# Altium schematic sheet lumiere_ctrl_shematic.SchDoc
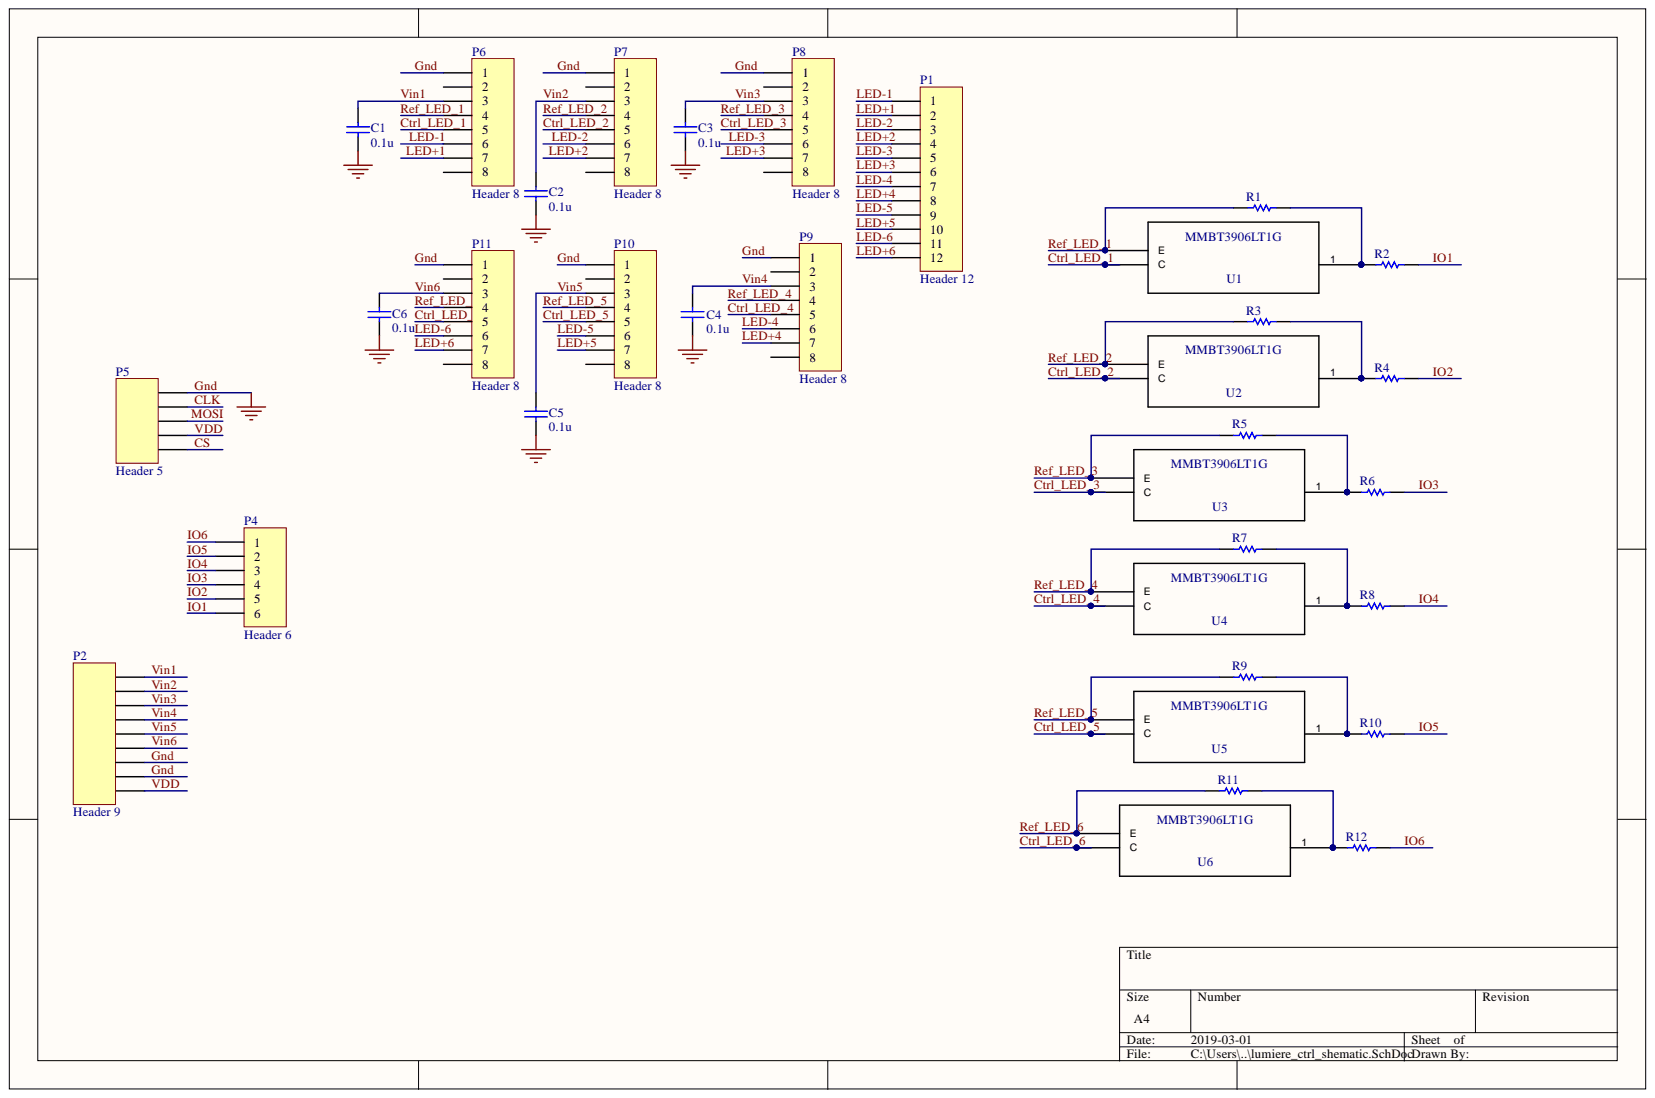
[diagram p0: lumiere_ctrl_shematic.SchDoc]

## Parts
C1  value 0.1u  [CC1206N]  (hidden comment: CapSMD)
C2  value 0.1u  [CC1206N]  (hidden comment: CapSMD)
C3  value 0.1u  [CC1206N]  (hidden comment: CapSMD)
C4  value 0.1u  [CC1206N]  (hidden comment: CapSMD)
C5  value 0.1u  [CC1206N]  (hidden comment: CapSMD)
C6  value 0.1u  [CC1206N]  (hidden comment: CapSMD)
P1  Header 12  [Terminal block 12 pos]
P2  Header 9  [Terminal block 9 pos]
P4  Header 6  [HDR1X6]
P5  Header 5  [HDR1X5]
P6  Header 8  [HDR1X8]
P7  Header 8  [HDR1X8]
P8  Header 8  [HDR1X8]
P9  Header 8  [HDR1X8]
P10  Header 8  [HDR1X8]
P11  Header 8  [HDR1X8]
R1  ResSMD  [STA_RMCF1206]  (value not drawn)
R2  ResSMD  [STA_RMCF1206]  (value not drawn)
R3  ResSMD  [STA_RMCF1206]  (value not drawn)
R4  ResSMD  [STA_RMCF1206]  (value not drawn)
R5  ResSMD  [STA_RMCF1206]  (value not drawn)
R6  ResSMD  [STA_RMCF1206]  (value not drawn)
R7  ResSMD  [STA_RMCF1206]  (value not drawn)
R8  ResSMD  [STA_RMCF1206]  (value not drawn)
R9  ResSMD  [STA_RMCF1206]  (value not drawn)
R10  ResSMD  [STA_RMCF1206]  (value not drawn)
R11  ResSMD  [STA_RMCF1206]  (value not drawn)
R12  ResSMD  [STA_RMCF1206]  (value not drawn)
U1  MMBT3906LT1G  [SOT-23]
U2  MMBT3906LT1G  [SOT-23]
U3  MMBT3906LT1G  [SOT-23]
U4  MMBT3906LT1G  [SOT-23]
U5  MMBT3906LT1G  [SOT-23]
U6  MMBT3906LT1G  [SOT-23]

## Nets
CLK: P5.4
CS: P5.1
Ctrl_LED_1: P6.5 U1.3
Ctrl_LED_2: P7.5 U2.3
Ctrl_LED_3: P8.5 U3.3
Ctrl_LED_4: P9.5 U4.3
Ctrl_LED_5: P10.5 U5.3
Ctrl_LED_6: P11.5 U6.3
Gnd: C1.1 C2.1 C3.1 C4.1 C5.1 C6.1 P2.2 P2.3 P5.5 P6.1 P7.1 P8.1 P9.1 P10.1 P11.1
IO1: P4.6 R2.1
IO2: P4.5 R4.1
IO3: P4.4 R6.1
IO4: P4.3 R8.1
IO5: P4.2 R10.1
IO6: P4.1 R12.1
LED+1: P1.2 P6.7
LED+2: P1.4 P7.7
LED+3: P1.6 P8.7
LED+4: P1.8 P9.7
LED+5: P1.10 P10.7
LED+6: P1.12 P11.7
LED-1: P1.1 P6.6
LED-2: P1.3 P7.6
LED-3: P1.5 P8.6
LED-4: P1.7 P9.6
LED-5: P1.9 P10.6
LED-6: P1.11 P11.6
MOSI: P5.3
Ref_LED_1: P6.4 R1.2 U1.2
Ref_LED_2: P7.4 R3.2 U2.2
Ref_LED_3: P8.4 R5.2 U3.2
Ref_LED_4: P9.4 R7.2 U4.2
Ref_LED_5: P10.4 R9.2 U5.2
Ref_LED_6: P11.4 R11.2 U6.2
VDD: P2.1 P5.2
Vin1: C1.2 P2.9 P6.3
Vin2: C2.2 P2.8 P7.3
Vin3: C3.2 P2.7 P8.3
Vin4: C4.2 P2.6 P9.3
Vin5: C5.2 P2.5 P10.3
Vin6: C6.2 P2.4 P11.3
NetR1_1: R1.1 R2.2 U1.1
NetR3_1: R3.1 R4.2 U2.1
NetR5_1: R5.1 R6.2 U3.1
NetR7_1: R7.1 R8.2 U4.1
NetR9_1: R9.1 R10.2 U5.1
NetR11_1: R11.1 R12.2 U6.1

Not connected: P6 2 8; P7 2 8; P8 2 8; P9 2 8; P10 2 8; P11 2 8

## Library data of the parts
C1-C6 = CapSMD: Capacitor; Type: Ceramic; Voltage: 50V; Component Kind: Standard; Package: 1206; Simulation: Model Name; Library Name: S3_projet.IntLib
P1 = Header 12: Header, 12-Pin; Component Kind: Standard; LatestRevisionDate: 17-Jul-2002; LatestRevisionNote: Re-released for DXP Platform.; Library Name: Miscellaneous Connectors.IntLib; Signal Integrity: Connector; Publisher: Altium Limited
P2 = Header 9: Header, 9-Pin; Component Kind: Standard; LatestRevisionDate: 17-Jul-2002; LatestRevisionNote: Re-released for DXP Platform.; Library Name: Miscellaneous Connectors.IntLib; Signal Integrity: Connector; Publisher: Altium Limited
P4 = Header 6: Header, 6-Pin; Component Kind: Standard; LatestRevisionDate: 17-Jul-2002; LatestRevisionNote: Re-released for DXP Platform.; Library Name: Miscellaneous Connectors.IntLib; Signal Integrity: Connector; Publisher: Altium Limited
P5 = Header 5: Header, 5-Pin; Component Kind: Standard; LatestRevisionDate: 17-Jul-2002; LatestRevisionNote: Re-released for DXP Platform.; Library Name: Miscellaneous Connectors.IntLib; Signal Integrity: Connector; Publisher: Altium Limited
P6-P11 = Header 8: Header, 8-Pin; Component Kind: Standard; LatestRevisionDate: 17-Jul-2002; LatestRevisionNote: Re-released for DXP Platform.; Library Name: Miscellaneous Connectors.IntLib; Signal Integrity: Connector; Publisher: Altium Limited
U1-U6 = MMBT3906LT1G: Built_By: EMA_UL_Team; C: Copyright (C) 2015 Accelerated Designs. All rights reserved; Vendor: ON Semiconductor; Component Kind: Standard; Library Name: AltiumV15.SchLib; Manufacturer_Part_Number: MMBT3906LT1G; Value: MMBT3906LT1G
R1-R12 = ResSMD: Component Kind: Standard; Package: 1206; Simulation: Model Name; Library Name: S3_projet.IntLib
